# Altium schematic sheet ETHERNET.SchDoc(ETHERNET)
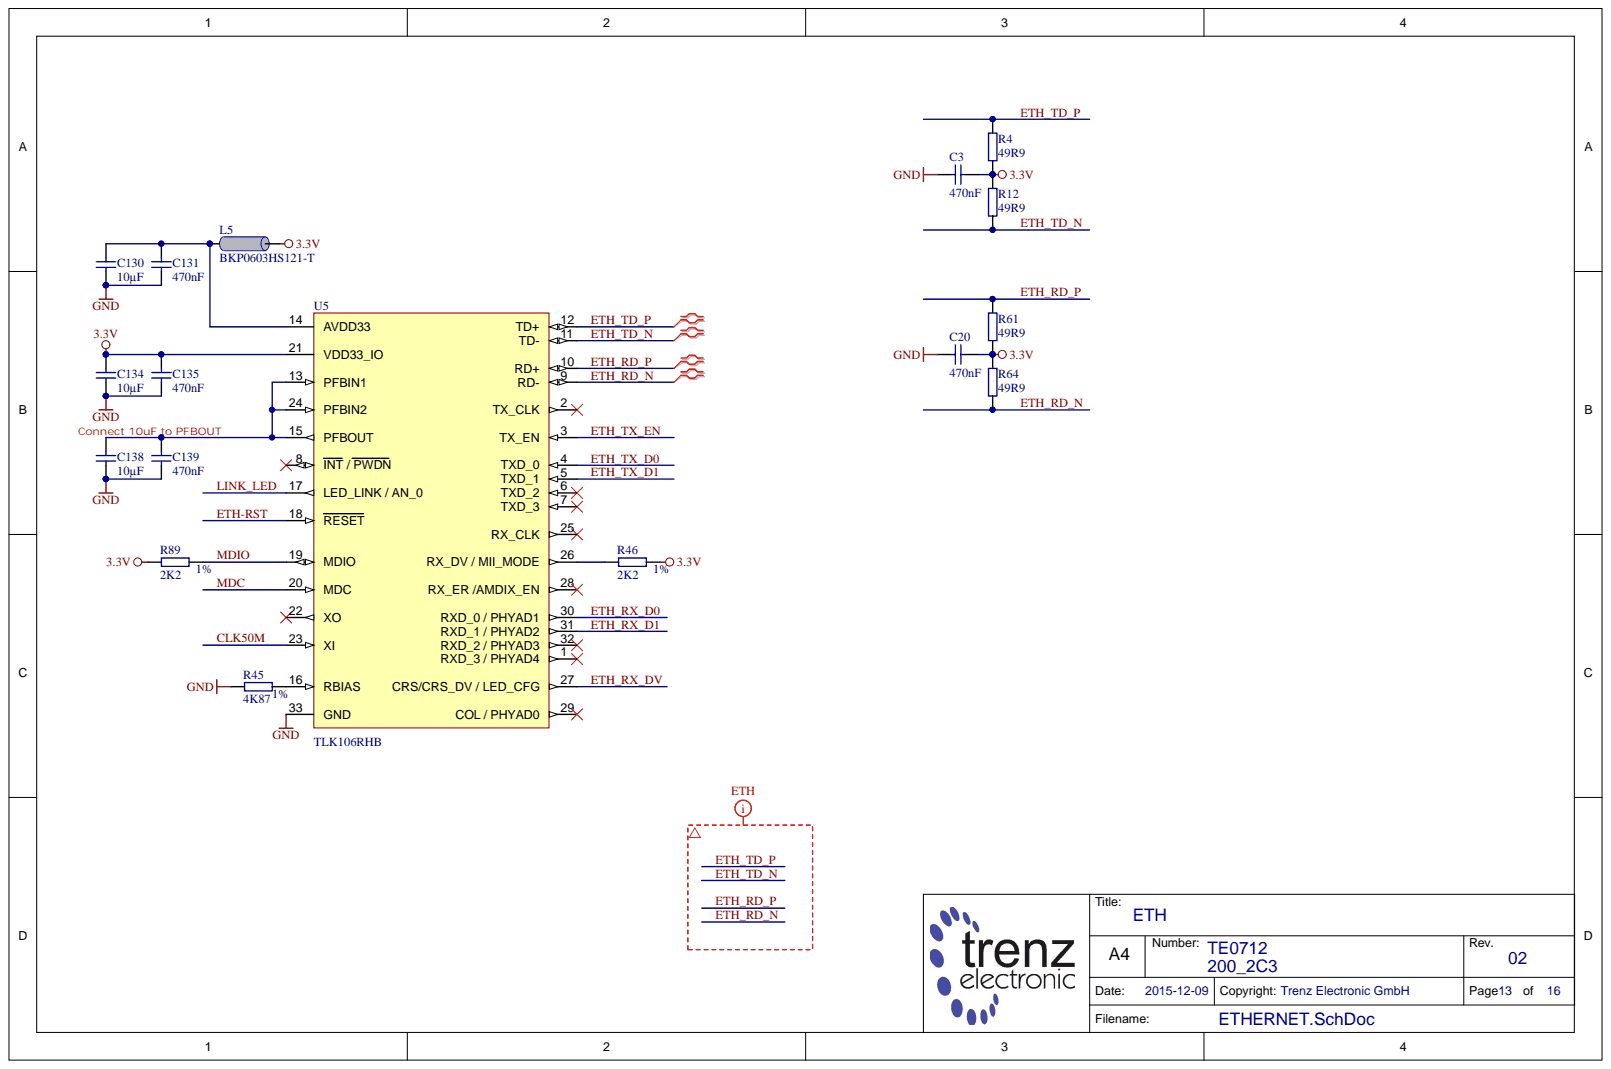
[diagram p0: ETHERNET.SchDoc]

## Parts
C3  470nF  [CAPC0603X33N]
C20  470nF  [CAPC0603X33N]
C130  10µF  [CAPC1608X90N]
C131  470nF  [CAPC0603X33N]
C134  10µF  [CAPC1608X90N]
C135  470nF  [CAPC0603X33N]
C138  10µF  [CAPC1608X90N]
C139  470nF  [CAPC0603X33N]
L5  BKP0603HS121-T  [INDC0603X33N]
R4  49R9  [RESC1005X40N]
R12  49R9  [RESC1005X40N]
R45  4K87  Tolerance: 1%  [RESC1005X40N]
R46  2K2  Tolerance: 1%  [RESC1005X40N]
R61  49R9  [RESC1005X40N]
R64  49R9  [RESC1005X40N]
R89  2K2  Tolerance: 1%  [RESC1005X40N]
U5  TLK106RHB  [QFN50P500X500X100-33N-300]

## Nets
3.3V: C3.2 C20.2 C134.1 C135.1 L5.2 R4.2 R12.1 R46.1 R61.2 R64.1 R89.2 U5.21(VDD33_IO)
GND: C3.1 C20.1 C130.2 C131.2 C134.2 C135.2 C138.2 C139.2 R45.1 U5.33(GND)
CLK50M: U5.23(XI)
ETH-RST: U5.18(RESET)
ETH_RD_N: R64.2 U5.9(RD-)
ETH_RD_P: R61.1 U5.10(RD+)
ETH_RX_D0: U5.30(RXD_0_/_PHYAD1)
ETH_RX_D1: U5.31(RXD_1_/_PHYAD2)
ETH_RX_DV: U5.27(CRS/CRS_DV_/_LED_CFG)
ETH_TD_N: R12.2 U5.11(TD-)
ETH_TD_P: R4.1 U5.12(TD+)
ETH_TX_D0: U5.4(TXD_0)
ETH_TX_D1: U5.5(TXD_1)
ETH_TX_EN: U5.3(TX_EN)
LINK_LED: U5.17(LED_LINK_/_AN_0)
MDC: U5.20(MDC)
MDIO: R89.1 U5.19(MDIO)
NetC130_1: C130.1 C131.1 L5.1 U5.14(AVDD33)
NetC138_1: C138.1 C139.1 U5.13(PFBIN1) U5.15(PFBOUT) U5.24(PFBIN2)
NetR45_2: R45.2 U5.16(RBIAS)
NetR46_2: R46.2 U5.26(RX_DV_/_MII_MODE)
N00698:

Not connected: U5 1(RXD_3_/_PHYAD4) 2(TX_CLK) 6(TXD_2) 7(TXD_3) 8(INT_/_PWDN) 22(XO) 25(RX_CLK) 28(RX_ER_/AMDIX_EN) 29(COL_/_PHYAD0) 32(RXD_2_/_PHYAD3)

## Library data of the parts
C3 C20 C131 C135 C139 = 24921: KON 470nF X5R 6.3V SMD 20%; Database Table Name: C_0603_0201; Mixed: SMT; Preferred: Yes; Value: 470n; AN: 24921; Case: 0603 (0201); Component Kind: Standard; Library Name: TE.DbLib; Technology: X5R; Tolerance: 20%; Voltage: 6.3V
C130 C134 C138 = 25341: KON 10µF 16V X5R SMD 20%; Database Table Name: C_1608_0603; Mixed: SMT; Preferred: Yes; Value: 10u; AN: 25341; Case: 1608 (0603); Component Kind: Standard; Library Name: TE.DbLib; Technology: X5R; Tolerance: 20%; Voltage: 16V
L5 = 25393: Ferritdurchführung SMD 0201; Database Table Name: L_0603_0201; Mixed: SMT; Preferred: Yes; Tolerance: 25%; AN: 25393; Case: 0603 (0201); Component Kind: Standard; H-ART: BKP0603HS121-T; Library Name: TE.DbLib; Trenz-Stock: Yes; HelpURL: B:\AD\adlib\datasheet\MULTILAYER CHIP BEAD INDUCTORS BK
R4 R12 R61 R64 = 23135: WID SMD 49R9 1% 0,063W; Database Table Name: R_1005_0402; Mixed: SMT; Preferred: Yes; Value: 49.9; AN: 23135; Case: 1005 (0402); Component Kind: Standard; Library Name: TE.DbLib
R45 = 25921: WID SMD 4K87 1% 0,063W; Database Table Name: R_1005_0402; Mixed: SMT; Preferred: Yes; Value: 4.87k; AN: 25921; Case: 1005 (0402); Component Kind: Standard; Library Name: TE.DbLib
R46 R89 = 22748: WID SMD 2K2 1% 0,063W; Database Table Name: R_1005_0402; Mixed: SMT; Preferred: Yes; Value: 2.2K; AN: 22748; Case: 1005 (0402); Component Kind: Standard; Library Name: TE.DbLib
U5 = 25350: Database Table Name: IF; Mixed: SMT; Trenz-Stock: Yes; AN: 25350; Case: RHB (S-PQFN-N32); Component Kind: Standard; Description: TLK106RHB; Library Name: TE.DbLib; HelpURL: B:\AD\adlib\datasheet\TLK106
